# Altium schematic sheet switch_peripherials.SchDoc
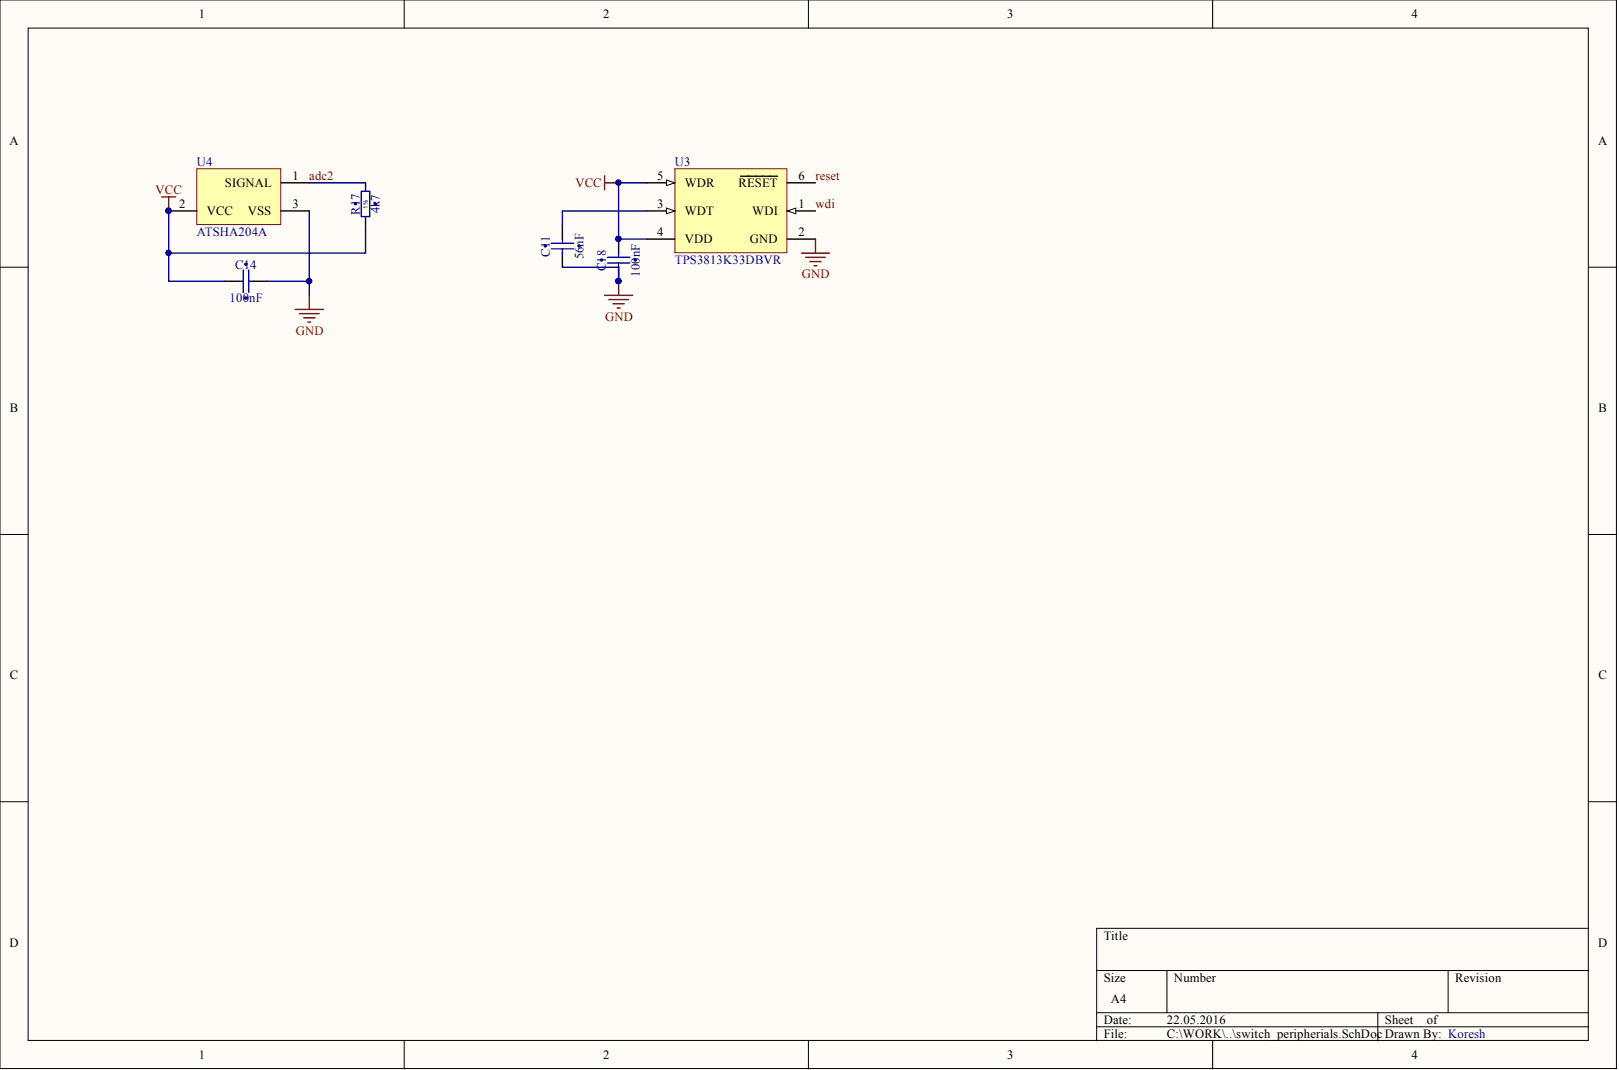
[diagram p0: switch_peripherials.SchDoc]

## Parts
C11  56nF  [CAPC1005X55N]
C14  100nF  [CAPC1005X55N]
C18  100nF  [CAPC1005X55N]
R17  4k7  [RESC1005X40N]
U3  TPS3813K33DBVR  [DBV6_L]
U4  ATSHA204A  [3TS1_N]

## Nets
GND: C11.1 C14.2 C18.1 U3.2(GND) U4.3
VCC: C14.1 C18.2 R17.1 U3.4(VDD) U3.5(WDR) U4.2
ADC2: R17.2 U4.1
RESET: U3.6(RESET)
WDI: U3.1(WDI)
NetC11_2: C11.2 U3.3(WDT)

## Library data of the parts
C11 = CC0402_68NF_16V_10%_X7R: Non-polarised capacitor; Manufacturer: GENERIC; Manufacturer1 Part Number: 223878715647; Part Description: SMD Multilayer Chip Ceramic Capacitor; Part Number: CC0402_56NF_16V_10%_X7R; Database Table Name: Capacitors SMD; TC: X7R; Tolerance: ±10%; Voltage: 16V; Case: 0402; Component Kind: Standard; Component Type: Standard; CreateDate: 03.12.2007; HelpURL: \\cern.ch\dfs\Applications\Altium\Datasheets\CC0402_X7R_PHYCOMP.pdf; LatestRevisionDate: 03.12.2007; Library Name: Capacitors.DbLib; Manufacturer1 ComponentHeight: 0.55mm; Manufacturer1 Example: PHYCOMP; Manufacturer Part Number: CC0402_68NF_16V_10%_X7R; Mounted: Yes; Pin Count: 2; SMD: Yes; Socket: No; Status: Not Recommended; Table: Capacitors SMD; Value: 56nF; Author: CERN DEM JLC
C14 C18 = CC0402_100NF_16V_10%_X5R: Non-polarised capacitor; Manufacturer: GENERIC; Manufacturer1 Part Number: GRM155R61C104KA88D; Part Description: SMD Multilayer Chip Ceramic Capacitor; Database Table Name: Capacitors SMD; TC: X5R; Tolerance: ±10%; Voltage: 16V; Case: 0402; Component Kind: Standard; Component Type: Standard; CreateDate: 10.12.2013; HelpURL: \\cern.ch\dfs\Applications\Altium\Datasheets\CC0402_X5R_MURATA_GRM.pdf; LatestRevisionDate: 10.12.2013; Library Name: Capacitors.DbLib; Manufacturer1 ComponentHeight: 0.55mm; Manufacturer1 Example: MURATA; Manufacturer Part Number: CC0402_100NF_16V_10%_X5R; Mounted: Yes; Part Number: CC0402_100NF_16V_10%_X5R; Pin Count: 2; SMD: Yes; Socket: No; Status: None; Table: Capacitors SMD; Value: 100nF; Author: CERN DEM JLC
R17 = R0402_4K7_1%_0.0625W_100PPM: Resistor - 1%; Manufacturer: GENERIC; Manufacturer1 Part Number: 232270674702L; Part Description: General Purpose Thick Film Chip Resistor; Database Table Name: Resistors SMD; TC: ±100ppm/°C; Case: 0402; Component Kind: Standard; Component Type: Standard; CreateDate: 03.12.2007; HelpURL: \\cern.ch\dfs\Applications\Altium\Datasheets\R0402_Phycomp_RC0402.pdf; LatestRevisionDate: 17.10.2012; Library Name: Resistors.DbLib; Manufacturer1 ComponentHeight: 0.4mm; Manufacturer1 Example: YAGEO PHYCOMP; Manufacturer Part Number: R0402_4K7_1%_0.0625W_100PPM; Mounted: Yes; Part Number: R0402_4K7_1%_0.0625W_100PPM; Pin Count: 2; Power: 0.0625W; SMD: Yes; Socket: No; Status: Not recommended; Table: Resistors SMD; Tolerance: ±1%; Value: 4k7; Author: CERN DEM JLC
U3 = CMP-0332-00489-1: Supervisor with Programmable Watchdog Window, 1 Supply Monitored, -40 to 85 degC, 6-pin SOT-23 (DBV), Green (RoHS & no Sb/Br); Manufacturer: Texas Instruments; # of supplies monitored: 1; Iq(Typ)(uA): 9; Output Driver Type / Reset Output: Active-low, Open-drain; Rating: Catalog; Reset Threshold Accuracy(%): 2.2; SubFamily: Supervisor and Reset IC; Threshold Voltage 1 (typ)(Typ)(V): 2.93; Time Delay(ms): 25; VCC(Max)(V): 6; VCC(Min)(V): 2; Code_JEDEC: MO-178-AB*; Component Kind: Standard; ComponentLink1Description: Datasheet; ComponentLink1URL: http://www.ti.com/general/docs/lit/getliterature.tsp?genericPartNumber=TPS3813K33&fileType=pdf; DatasheetVersion: SLVS331F; Library Name: Altium Content Vault; Mounting Technology: Surface Mount; PackageDescription: 6-Pin Plastic Small-Outline Package, 2.9 x 1.6 mm Body, 0.95 mm Pitch, 2.8 mm Lead Span; PackageReference: DBV6; PackageVersion: revK, Mar-2006; PartNumber: TPS3813K33DBVR; Watchdog Timer WDI(sec): Programmable
U4 = AT88SA10HS-TSU-T: CryptoAuthentication™ Host Security Chip, Industrial (-40 to 85 degC), 3-Pin SOT, Tape and Reel, Pb-Free; Code_IPC: SOT23-3; Code_JEDEC: TO-236-AB; Component Kind: Standard; ComponentLink1Description: Manufacturer URL; ComponentLink1URL: http://www.atmel.com/; ComponentLink2Description: Datasheet; ComponentLink2URL: http://www.atmel.com/dyn/resources/prod_documents/doc8595.pdf; DatasheetVersion: revD, May-2010; Library Name: Atmel Security Smart Card.IntLib; PackageDescription: 3-Pin Plastic Thin Shrink Small Outline Package, 2.9 x 1.3 mm Body, 0.95 mm Pitch (Shrink SOT); PackageReference: 3TS1; PackageVersion: revA,Nov-2008
